# Altium schematic sheet IMU.SchDoc
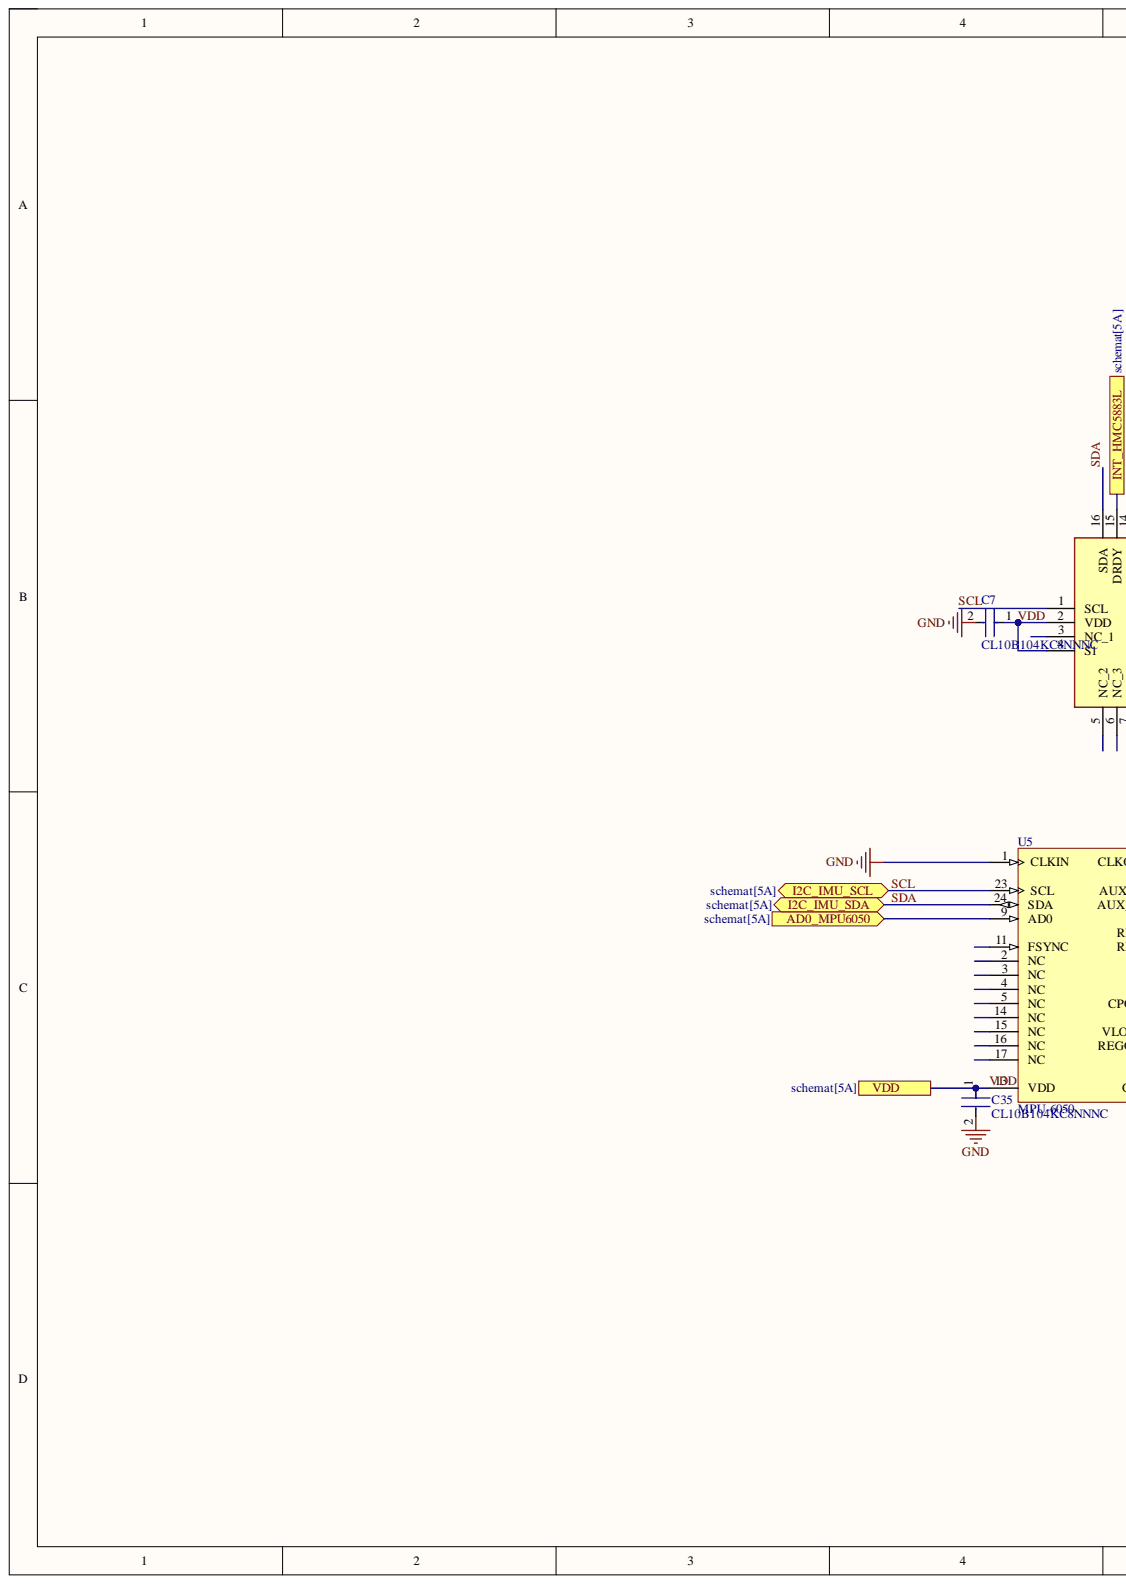
[diagram p0: IMU.SchDoc, left]
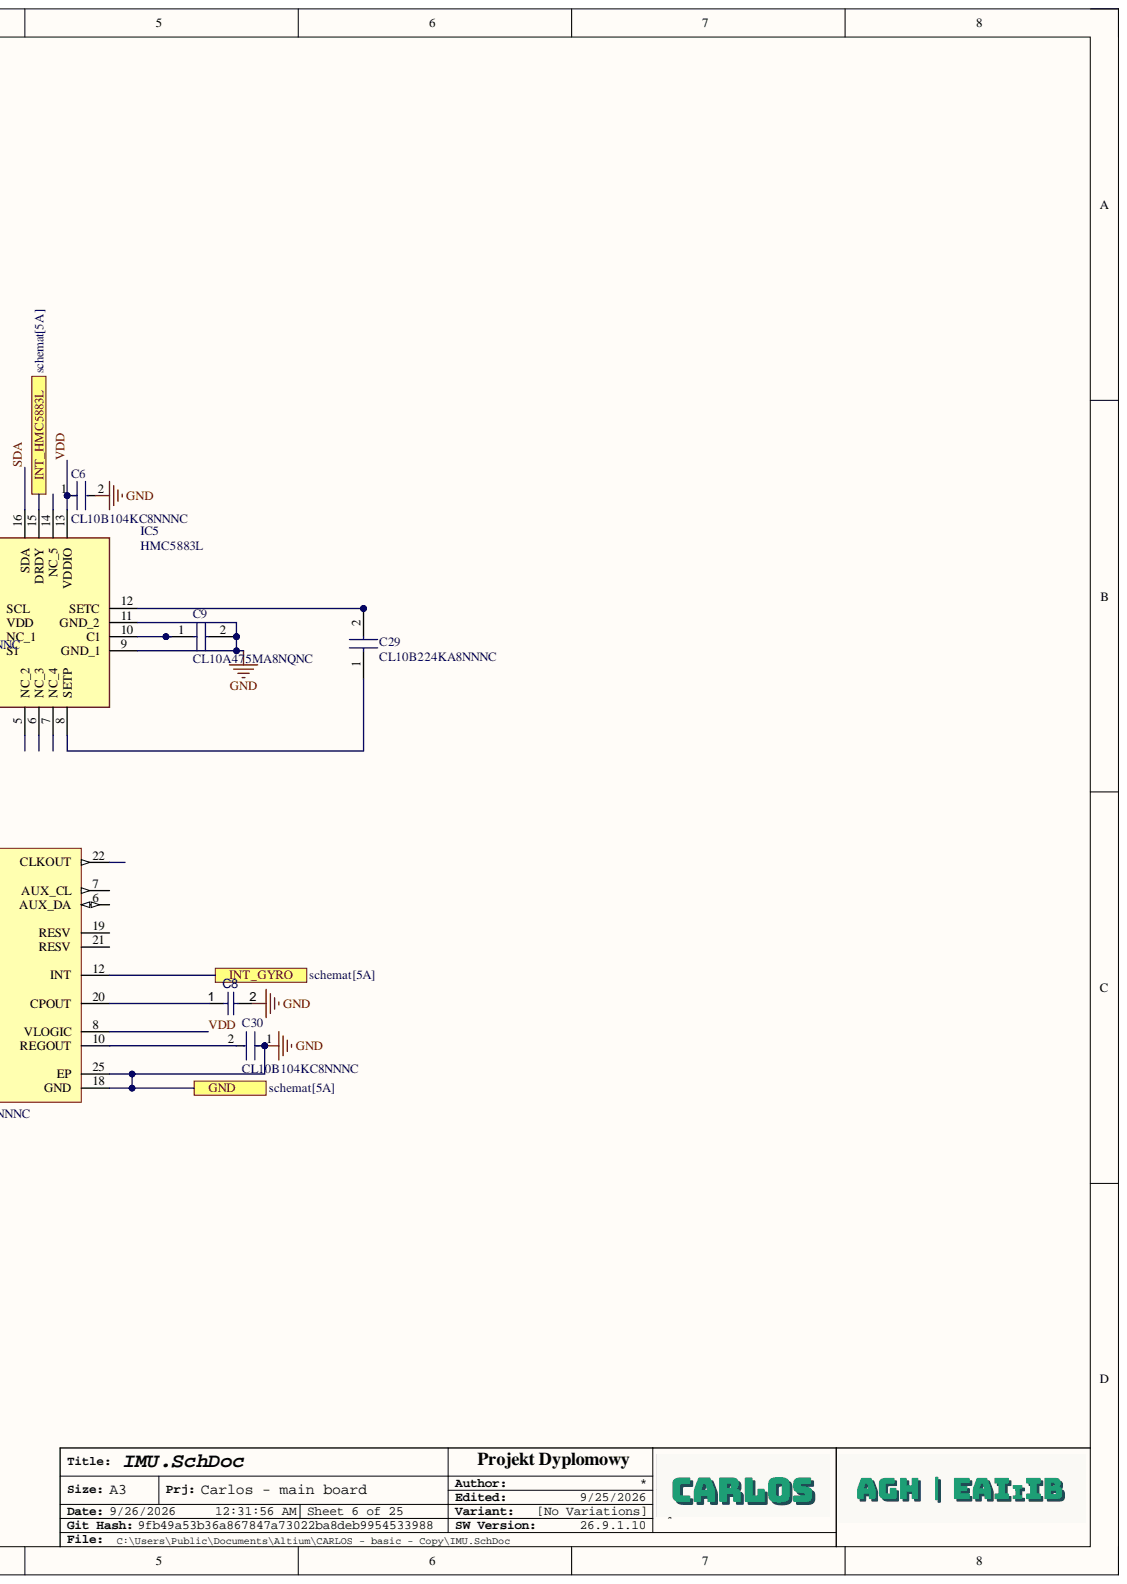
[diagram p1: IMU.SchDoc, right]

## Parts
C6  CL10B104KC8NNNC  [FP-CL10-IPC_A]
C7  CL10B104KC8NNNC  [FP-CL10-IPC_A]
C8  2.2nF  [0603]  (value not drawn)
C9  CL10A475MA8NQNC  [CAPC1608X95N]
C29  CL10B224KA8NNNC  [CAPC1608X90N]
C30  CL10B104KC8NNNC  [FP-CL10-IPC_A]
C35  CL10B104KC8NNNC  [FP-CL10-IPC_A]
IC5  HMC5883L  [HMC5883L]
U5  MPU-6050  [IS-QFN-24_V]

## Ports
AD0_MPU6050, GND, I2C_IMU_SCL, I2C_IMU_SDA, INT_GYRO, INT_HMC5883L, VDD

## Nets
AD0_MPU6050: U5.9(AD0) ; port AD0_MPU6050
GND: C6.2 C7.2 C8.2 C9.2 C30.1 C35.2 IC5.9(GND_1) IC5.11(GND_2) U5.1(CLKIN) U5.18(GND) U5.25(EP) ; port GND
INT_GYRO: U5.12(INT) ; port INT_GYRO
INT_HMC5883L: IC5.15(DRDY) ; port INT_HMC5883L
SCL: IC5.1(SCL) U5.23(SCL) ; port I2C_IMU_SCL
SDA: IC5.16(SDA) U5.24(SDA) ; port I2C_IMU_SDA
VDD: C6.1 C7.1 C35.1 IC5.2(VDD) IC5.4(S1) IC5.13(VDDIO) U5.8(VLOGIC) U5.13(VDD) ; port VDD
NetC8_1: C8.1 U5.20(CPOUT)
NetC9_1: C9.1 IC5.10(C1)
NetC29_1: C29.1 IC5.8(SETP)
NetC29_2: C29.2 IC5.12(SETC)
NetC30_2: C30.2 U5.10(REGOUT)

Not connected: IC5 3(NC_1) 5(NC_2) 6(NC_3) 7(NC_4) 14(NC_5); U5 2(NC) 3(NC) 4(NC) 5(NC) 6(AUX_DA) 7(AUX_CL) 11(FSYNC) 14(NC) 15(NC) 16(NC) 17(NC) 19(RESV) 21(RESV) 22(CLKOUT)

## Library data of the parts
C6 C7 C30 C35 = CMP-13271-004036-1: Cap Ceramic 100nF 100V X7R ±10% Pad SMD 0603 +125°C T/R; Capacitance: 100nF; Case Code (Imperial): 0603; Case Code (Metric): 1608; Case/Package: 0603; Component Kind: Standard; Length: 0.063inch; Library Name: Altium Content Vault; Max Operating Temperature: 125°C; Min Operating Temperature: -55°C; Mount: Surface Mount; Packaging: Cut Tape; Thickness: 0.035inch; Tolerance: 10%; Voltage Rating: 100V; Width: 0.031inch
C8 = CMP-001-00083-3: 0402/0603/0805/1206; Capacitor Type: MLCC; Case/Package: 0402/0603/0805/1206; Component Kind: Standard; Library Name: Jakub Sikora
C9 = CL10A475MA8NQNC: LEPIEJ CL10A475KA8NQNC C69335; Manufacturer_Name: SAMSUNG; Arrow Part Number: CL10A475MA8NQNC; Arrow Price/Stock: https://www.arrow.com/en/products/cl10a475ma8nqnc/samsung-electro-mechanics; Component Kind: Standard; Datasheet Link: https://datasheet.datasheetarchive.com/originals/distributors/Datasheets-DGA10/2414163.pdf; Height: 0.95mm; Manufacturer_Part_Number: CL10A475MA8NQNC; Mouser Part Number: 187-CL10A475MA8NQNC; Mouser Price/Stock: https://www.mouser.co.uk/ProductDetail/Samsung-Electro-Mechanics/CL10A475MA8NQNC?qs=%252B6g0mu59x7LVL0Mky24t3Q%3D%3D
C29 = CL10B224KA8NNNC: Capacitor; Manufacturer_Name: SAMSUNG; Arrow Part Number: CL10B224KA8NNNC; Arrow Price/Stock: https://www.arrow.com/en/products/cl10b224ka8nnnc/samsung-electro-mechanics?region=nac; Component Kind: Standard; Datasheet Link: https://datasheet.lcsc.com/szlcsc/Samsung-Electro-Mechanics-CL10B224KA8NNNC_C21120.pdf; Height: 0.9mm; Manufacturer_Part_Number: CL10B224KA8NNNC; Mouser Part Number: 187-CL10B224KA8NNNC; Mouser Price/Stock: https://www.mouser.co.uk/ProductDetail/Samsung-Electro-Mechanics/CL10B224KA8NNNC?qs=hqM3L16%252Bxler99i%2FC%2FTWQw%3D%3D
IC5 = HMC5883L: Integrated Circuit; Manufacturer_Name: Honeywell; Component Kind: Standard; Datasheet Link: http://www.farnell.com/datasheets/1683374.pdf; Height: 0.9mm; Manufacturer_Part_Number: HMC5883L
U5 = CMP-1753-00002-2: 3-Axis Gyroscope, Accelerometer and a Digital Motion Processor(TM) (DMP), 2.375 to 3.46 V, -40 to 85 degC, 24-Pin QFN, RoHS, Tape and Reel; Manufacturer: InvenSense; Dynamic Catalog: MPU-6000™Series; Featured Product: MPU-60506-Axis,IntegratedI²CSolution; Other Names: 1428-1007-2 MPU6050; Sensor Type: MotionTracking; Category: Sensors,Transducers; Component Kind: Standard; Datasheet URL: http://datasheet.octopart.com/MPU-6050-InvenSense-datasheet-14421344.pdf; Datasheet Version: Rev. 3.3, 05/2012; Family: Multifunction; Library Name: Altium Content Vault; Manufacturer URL: http://www.invensense.com/; Online Catalog: MPU-6000™Series; Output Type: Digital; Standard Package: 5,000; Unit PriceUSD: Digital
